# Altium schematic sheet ArduinoUNO.SchDoc
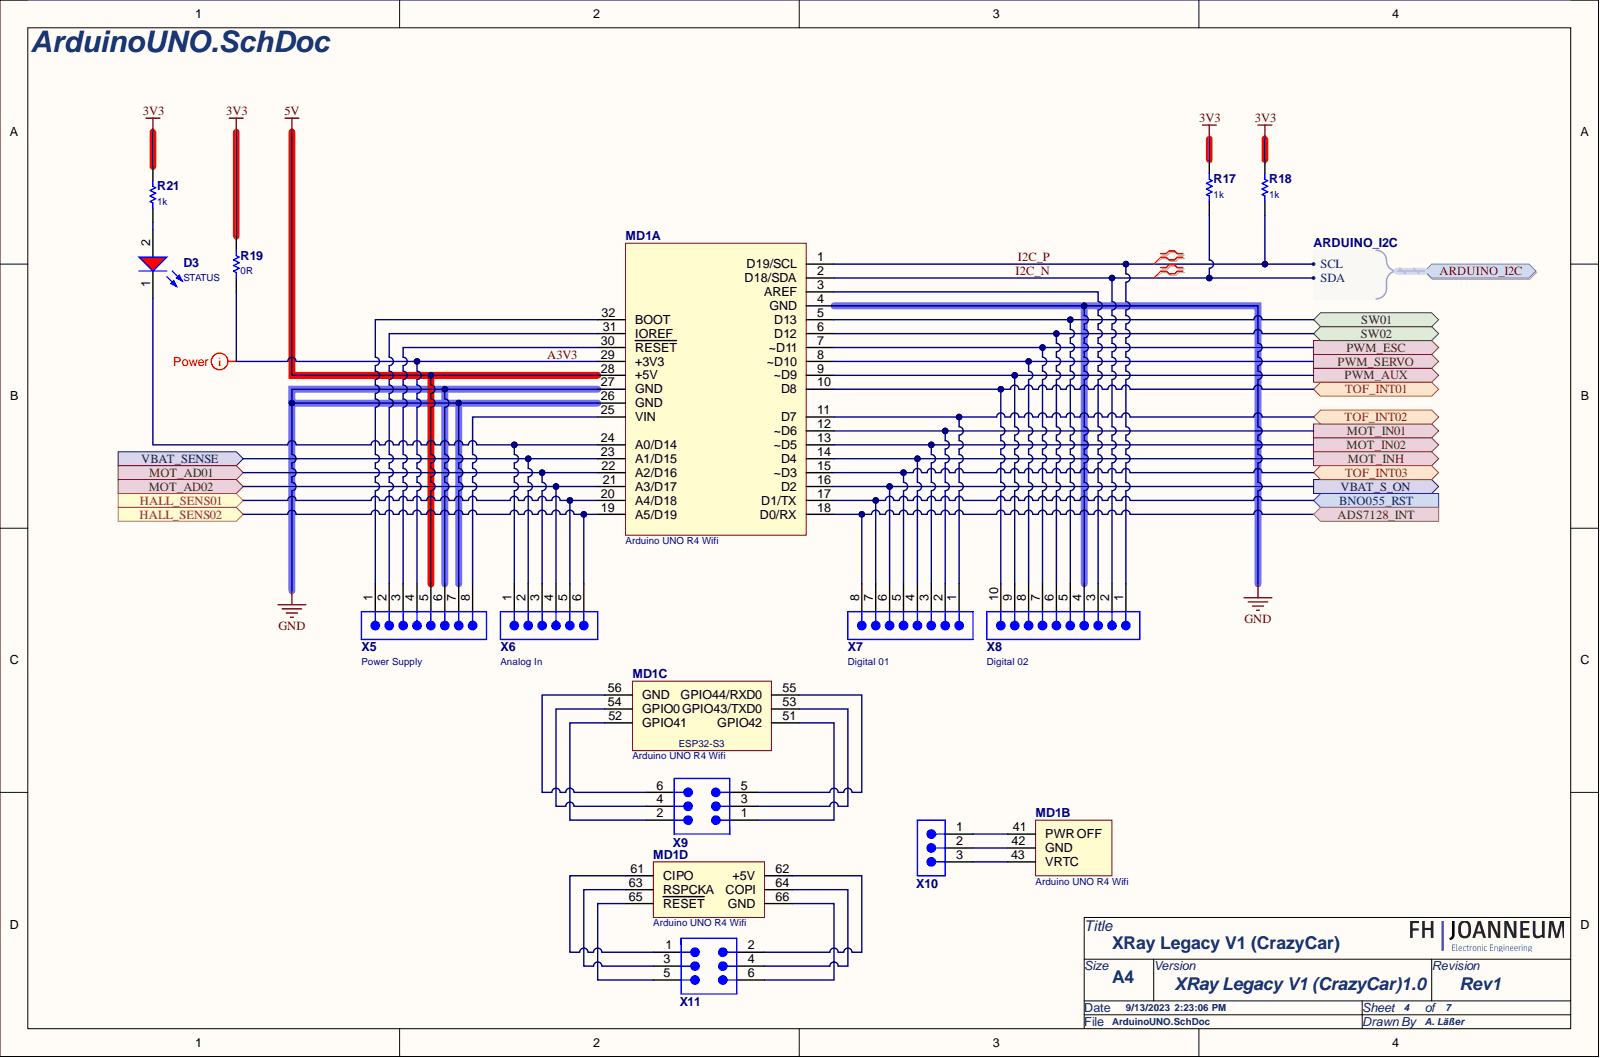
[diagram p0: ArduinoUNO.SchDoc]

## Parts
D3  STATUS  [WL-SMCC_0603_L1.6W0.8H0.2]
MD1  Arduino UNO R4 Wifi  [ARDUINO UNO R4 Wiki]  (parts A,B,C,D)
R17  Resistance: 1k  [RESC2013X60X35ML10T20]  (hidden comment: RC0805FR-071KL)
R18  Resistance: 1k  [RESC2013X60X35ML10T20]  (hidden comment: RC0805FR-071KL)
R19  Resistance: 0R  [RESC2013X60X35ML10T20]  (hidden comment: RC0805JR-070RL)
R21  Resistance: 1k  [RESC2013X60X35ML10T20]  (hidden comment: RC0805FR-071KL)
X5  Power Supply  [61300811121]
X6  Analog In  [61300611121]
X7  Digital 01  [61300811121]
X8  Digital 02  [61301011121]
X9  61300621121  [61300621121]  (value not drawn)
X10  61300311121  [61300311121]  (value not drawn)
X11  61300621121  [61300621121]  (value not drawn)

## Ports
ADS7128_INT, ARDUINO_I2C, ARDUINO_I2C.SCL, ARDUINO_I2C.SDA, BNO055_RST, HALL_SENS01, HALL_SENS02, MOT_AD01, MOT_AD02, MOT_IN01, MOT_IN02, MOT_INH, PWM_AUX, PWM_ESC, PWM_SERVO, SW01, SW02, TOF_INT01, TOF_INT02, TOF_INT03, VBAT_S_ON, VBAT_SENSE

## Nets
3V3: R17.1 R18.1 R19.2 R21.1
5V: MD1.28(+5V) X5.5
GND: MD1.4(GND) MD1.26(GND) MD1.27(GND) X5.6 X5.7 X8.4
A3V3: MD1.29(+3V3) R19.1 X5.4
ADS7128_INT: MD1.18(D0/RX) X7.8 ; port ADS7128_INT
BNO055_RST: MD1.17(D1/TX) X7.7 ; port BNO055_RST
HALL_SENS01: MD1.20(A4/D18) X6.5 ; port HALL_SENS01
HALL_SENS02: MD1.19(A5/D19) X6.6 ; port HALL_SENS02
I2C_N: MD1.2(D18/SDA) R17.2 X8.2 ; port ARDUINO_I2C
I2C_P: MD1.1(D19/SCL) R18.2 X8.1 ; port ARDUINO_I2C
MOT_AD01: MD1.22(A2/D16) X6.3 ; port MOT_AD01
MOT_AD02: MD1.21(A3/D17) X6.4 ; port MOT_AD02
MOT_IN01: MD1.12(~D6) X7.2 ; port MOT_IN01
MOT_IN02: MD1.13(~D5) X7.3 ; port MOT_IN02
MOT_INH: MD1.14(D4) X7.4 ; port MOT_INH
PWM_AUX: MD1.9(~D9) X8.9 ; port PWM_AUX
PWM_ESC: MD1.7(~D11) X8.7 ; port PWM_ESC
PWM_SERVO: MD1.8(~D10) X8.8 ; port PWM_SERVO
SW01: MD1.5(D13) X8.5 ; port SW01
SW02: MD1.6(D12) X8.6 ; port SW02
TOF_INT01: MD1.10(D8) X8.10 ; port TOF_INT01
TOF_INT02: MD1.11(D7) X7.1 ; port TOF_INT02
TOF_INT03: MD1.15(~D3) X7.5 ; port TOF_INT03
VBAT_S_ON: MD1.16(D2) X7.6 ; port VBAT_S_ON
VBAT_SENSE: MD1.23(A1/D15) X6.2 ; port VBAT_SENSE
NetD3_1: D3.1 MD1.24(A0/D14) X6.1
NetD3_2: D3.2 R21.2
NetMD1_3: MD1.3(AREF) X8.3
NetMD1_25: MD1.25(VIN) X5.8
NetMD1_30: MD1.30(RESET) X5.3
NetMD1_31: MD1.31(IOREF) X5.2
NetMD1_32: MD1.32(BOOT) X5.1
NetMD1_41: MD1.41(PWR_OFF) X10.1
NetMD1_42: MD1.42(GND) X10.2
NetMD1_43: MD1.43(VRTC) X10.3
NetMD1_51: MD1.51(GPIO42) X9.1
NetMD1_52: MD1.52(GPIO41) X9.2
NetMD1_53: MD1.53(GPIO43/TXD0) X9.3
NetMD1_54: MD1.54(GPIO0) X9.4
NetMD1_55: MD1.55(GPIO44/RXD0) X9.5
NetMD1_56: MD1.56(GND) X9.6
NetMD1_61: MD1.61(CIPO) X11.1
NetMD1_62: MD1.62(+5V) X11.2
NetMD1_63: MD1.63(RSPCKA) X11.3
NetMD1_64: MD1.64(COPI) X11.4
NetMD1_65: MD1.65(RESET) X11.5
NetMD1_66: MD1.66(GND) X11.6

## Library data of the parts
D3 = 150060RS73220: WL-SMCC Mono-color Chip LED Compact, size 0603, Red, 2V, 120°; Manufacturer: Wurth Elektronik; Case/Size Code: 0603; Chip Technology: AlInGaP; Dominant Wavelength: 624nm; Luminous Intensity: 90mcd; Peak Wavelength: 632nm; Category: Signal LEDs; Component Kind: Standard; ComponentLink1Description: Website Link; ComponentLink1URL: https://www.we-online.com/redexpert/article/150060RS73220?ad; ComponentLink2Description: Datasheet Link; ComponentLink2URL: https://www.we-online.com/redexpert/spec/150060RS73220?ad; Emitting Color: Red; Forward Voltage: 2V; Height: 0.2mm; Length: 1.6mm; Library Name: Altium_WL-SMCC (rev22b).IntLib; Manufacturer Part Number: 150060RS73220; Match Code: WL-SMCC; Mount: Surface Mount; Operating Temperature Max: 85°C; Operating Temperature Min: -40°C; Packaging: Tape and Reel; Simulation: 0603_150060RS73220; Viewing Angle: 120°; Width: 0.8mm
R17 R18 R21 = CMP-2100-03671-1: Chip Resistor, 1 KOhm, +/- 1%, 125 mW, -55 to 155 degC, 0805 (2012 Metric), RoHS, Tape and Reel; Manufacturer: Yageo; Additional Feature: LASER TRIMMABLE; Max Power Dissipation: 125mW; Package Height: 0.5mm; Package Length: 2mm; Package Width: 1.25mm; Rated Temperature: 70°C; Temperature Coefficient: 100ppm/degreesC; Voltage Rating: 150V; Case Code (Imperial): 0805; Case Code (Metric): 2012; Case/Package: 0805; Category: Chip SMD Resistors; Component Kind: Standard; ComponentLink1Description: https://datasheet.ciiva.com:443/pdfs/VipMasterIC/IC/YAGO/YAGO-S-A0008145938/YAGO-S-A0008145938-1.pdf?src-supplier=IHS+Markit; ComponentLink1URL: https://datasheet.ciiva.com:443/pdfs/VipMasterIC/IC/YAGO/YAGO-S-A0008145938/YAGO-S-A0008145938-1.pdf?src-supplier=IHS+Markit; ComponentLink2Description: https://datasheet.ciiva.com/7941/1686128-7941719.pdf?src-supplier=Element14; ComponentLink2URL: https://datasheet.ciiva.com/7941/1686128-7941719.pdf?src-supplier=Element14; ComponentLink3Description: https://datasheet.ciiva.com/46385/444164-46385020.pdf?src-supplier=Element14; ComponentLink3URL: https://datasheet.ciiva.com/46385/444164-46385020.pdf?src-supplier=Element14; ComponentLink4Description: https://datasheet.ciiva.com/2456/pyu-r-int-thick-7-2456976.pdf?src-supplier=Digi-Key; ComponentLink4URL: https://datasheet.ciiva.com/2456/pyu-r-int-thick-7-2456976.pdf?src-supplier=Digi-Key; ComponentLink5Description: https://datasheet.ciiva.com/2456/pyu-r-int-thick-7-2456977.pdf?src-supplier=Digi-Key; ComponentLink5URL: https://datasheet.ciiva.com/2456/pyu-r-int-thick-7-2456977.pdf?src-supplier=Digi-Key; ComponentLink6Description: https://datasheet.ciiva.com/2456/pyu-r-int-thick-7-2456975.pdf?src-supplier=Digi-Key; ComponentLink6URL: https://datasheet.ciiva.com/2456/pyu-r-int-thick-7-2456975.pdf?src-supplier=Digi-Key; ComponentLink7Description: https://datasheet.ciiva.com/16850/rc0805-16850409.pdf?src-supplier=Future+Electronics; ComponentLink7URL: https://datasheet.ciiva.com/16850/rc0805-16850409.pdf?src-supplier=Future+Electronics; Composition: ThickFilm; Construction: Chip; Datasheet URL: http://www.yageo.com/NewPortal/yageodocoutput?fileName=/pdf/R-Chip/PYu-RC_Group_51_RoHS_L_5.pdf; Datasheet Version: Rev. 5, 10/2016; Depth: 1.25mm; Height: 0.024inch; JESD-609 Code: e3; Lead Free: LeadFree; Length: 0.079inch; Library Name: Altium Content Vault; Manufacturer Part Number: RC0805FR-071KL; Manufacturer URL: http://www.yageo.com/; Max Operating Temperature: 155degC; Military Standard: Not; Min Operating Temperature: -55degC; Mount: Surface Mount; Number of Pins: 2; Number of Terminations: 2; Package Description: 2-Pin Surface Mounted Device, Body 2 x 1.25 mm; Package Quantity: 1; Package Reference: 0805; Package Shape: Rectangular; Package Style: SMTMeter; Packaging: TapeandReel; Power Rating: 125mW; Pricing 1: 5000=0.00500 (USD); Rated Power Dissipation (P): 125mW; REACH SVHC: Unknown; Resistor Type: FIXED RESISTOR; RoHS: Yes; RoHS Compliant: Yes; RoHSCompliant: true; Size Code: 0805; Stock 1: 490000; Supplier 1: RSComponents; Supplier Part Number 1: 6183583; Technology: METAL GLAZE/THICK FILM; Terminal Finish: Nickel; Termination: SMD/SMT; Tolerance: 1%; Voltage Rating (DC): 150V; Width: 0.049inch; Working Voltage: 150V
R19 = CMP-2100-03673-1: Chip Resistor, 0 Ohm, Jumper, +/- 5%, 0.125 W, -55 to 155 degC, 0805 (2012 Metric), RoHS, Tape and Reel; Manufacturer: Yageo; Package Height: 0.5mm; Package Length: 2mm; Package Width: 1.25mm; Power Rating: 125mW; Rated Temperature: 70°C; Temperature Coefficient: 200ppm/degreesC; Voltage Rating: 150V; Case Code (Imperial): 0805; Case Code (Metric): 2012; Case/Package: 0805; Category: Chip SMD Resistors; Component Kind: Standard; ComponentLink1Description: https://datasheet.ciiva.com:443/pdfs/VipMasterIC/IC/YAGO/YAGO-S-A0008145938/YAGO-S-A0008145938-1.pdf?src-supplier=IHS+Markit; ComponentLink1URL: https://datasheet.ciiva.com:443/pdfs/VipMasterIC/IC/YAGO/YAGO-S-A0008145938/YAGO-S-A0008145938-1.pdf?src-supplier=IHS+Markit; ComponentLink2Description: https://datasheet.ciiva.com/11819/0900766b8106beab-11819430.pdf?src-supplier=RSComponents; ComponentLink2URL: https://datasheet.ciiva.com/11819/0900766b8106beab-11819430.pdf?src-supplier=RSComponents; ComponentLink3Description: https://datasheet.ciiva.com/7941/1738694-7941861.pdf?src-supplier=Element14; ComponentLink3URL: https://datasheet.ciiva.com/7941/1738694-7941861.pdf?src-supplier=Element14; ComponentLink4Description: https://datasheet.ciiva.com/7941/1738694-7941862.pdf?src-supplier=Element14; ComponentLink4URL: https://datasheet.ciiva.com/7941/1738694-7941862.pdf?src-supplier=Element14; ComponentLink5Description: https://datasheet.ciiva.com/7941/1738694-7941863.pdf?src-supplier=Element14; ComponentLink5URL: https://datasheet.ciiva.com/7941/1738694-7941863.pdf?src-supplier=Element14; ComponentLink6Description: https://datasheet.ciiva.com/15190/getdatasheetpartid-330685-15190028.pdf?src-supplier=Verical; ComponentLink6URL: https://datasheet.ciiva.com/15190/getdatasheetpartid-330685-15190028.pdf?src-supplier=Verical; Composition: ThickFilm; Construction: Chip; Contact Plating: Tin; Datasheet URL: http://www.yageo.com/NewPortal/yageodocoutput?fileName=/pdf/R-Chip/PYu-RC_Group_51_RoHS_L_5.pdf; Datasheet Version: Rev. 5, 10/2016; Depth: 1.25mm; Height: 0.5mm; JESD-609 Code: e3; Lead Free: LeadFree; Length: 2mm; Library Name: Altium Content Vault; Manufacturer Part Number: RC0805JR-070RL; Manufacturer URL: http://www.yageo.com/; Max Operating Temperature: 155degC; Military Standard: Not; Min Operating Temperature: -55degC; Mount: Surface Mount; Number of Pins: 2; Package Description: 2-Pin Surface Mounted Device, Body 2 x 1.25 mm; Package Reference: 0805; Package Shape: Rectangular; Package Style: SMTMeter; Packaging: TapeandReel; Pricing 1: 100=0.00700, 1000=0.00700, 2500=0.00600, 5000=0.00600, 10000=0.00500 (GBP); Radiation Hardening: No; Rated Power Dissipation (P): 125mW; REACH SVHC: Unknown; Resistor Type: FIXED RESISTOR; RoHS: Yes; RoHS Compliant: Yes; RoHSCompliant: true; Size Code: 0805; Stock 1: 1700; Supplier 1: RSComponents; Supplier Part Number 1: 1995753; Technology: METAL GLAZE/THICK FILM; Terminal Finish: Nickel; Termination: SMD/SMT; Tolerance: 5%; Voltage Rating (DC): 150V; Width: 1.25mm
X5 X7 = 61300811121: WR-PHD Pin Header, THT, Vertical, pitch 2.54mm, 1 Row, 8P; Manufacturer: Wurth Elektronik; Contact Resistance: 20mΩ; Rated Current: 3A; Withstanding Voltage: 500V; Working Voltage: 250V; Category: Board-to-Board Connectors; Component Kind: Standard; ComponentLink1Description: Website Link; ComponentLink1URL: https://www.we-online.com/redexpert/article/61300811121?ad; ComponentLink2Description: Datasheet Link; ComponentLink2URL: https://www.we-online.com/redexpert/spec/61300811121?ad; Gender: Pin Header; Library Name: Altium_WR-PHD (rev22b).IntLib; Manufacturer Part Number: 61300811121; Match Code: WR-PHD; Mount: Through Hole; Number of Pins: 8; Number of Rows: 1; Operating Temperature Max: 105°C; Operating Temperature Min: -40°C; Orientation: Vertical; Packaging: Bag; Pitch: 2.54mm
X6 = 61300611121: WR-PHD Pin Header, THT, Vertical, pitch 2.54mm, 1 Row, 6P; Manufacturer: Wurth Elektronik; Contact Resistance: 20mΩ; Rated Current: 3A; Withstanding Voltage: 500V; Working Voltage: 250V; Category: Board-to-Board Connectors; Component Kind: Standard; ComponentLink1Description: Website Link; ComponentLink1URL: https://www.we-online.com/redexpert/article/61300611121?ad; ComponentLink2Description: Datasheet Link; ComponentLink2URL: https://www.we-online.com/redexpert/spec/61300611121?ad; Gender: Pin Header; Library Name: Altium_WR-PHD (rev22b).IntLib; Manufacturer Part Number: 61300611121; Match Code: WR-PHD; Mount: Through Hole; Number of Pins: 6; Number of Rows: 1; Operating Temperature Max: 105°C; Operating Temperature Min: -40°C; Orientation: Vertical; Packaging: Bag; Pitch: 2.54mm
X8 = 61301011121: WR-PHD Pin Header, THT, Vertical, pitch 2.54mm, 1 Row, 10P; Manufacturer: Wurth Elektronik; Contact Resistance: 20mΩ; Rated Current: 3A; Withstanding Voltage: 500V; Working Voltage: 250V; Category: Board-to-Board Connectors; Component Kind: Standard; ComponentLink1Description: Website Link; ComponentLink1URL: https://www.we-online.com/redexpert/article/61301011121?ad; ComponentLink2Description: Datasheet Link; ComponentLink2URL: https://www.we-online.com/redexpert/spec/61301011121?ad; Gender: Pin Header; Library Name: Altium_WR-PHD (rev22b).IntLib; Manufacturer Part Number: 61301011121; Match Code: WR-PHD; Mount: Through Hole; Number of Pins: 10; Number of Rows: 1; Operating Temperature Max: 105°C; Operating Temperature Min: -40°C; Orientation: Vertical; Packaging: Bag; Pitch: 2.54mm
X9 X11 = 61300621121: WR-PHD Pin Header, THT, Vertical, pitch 2.54mm, 2 Row, 6P; Manufacturer: Wurth Elektronik; Contact Resistance: 20mΩ; Rated Current: 3A; Withstanding Voltage: 500V; Working Voltage: 250V; Category: Board-to-Board Connectors; Component Kind: Standard; ComponentLink1Description: Website Link; ComponentLink1URL: https://www.we-online.com/redexpert/article/61300621121?ad; ComponentLink2Description: Datasheet Link; ComponentLink2URL: https://www.we-online.com/redexpert/spec/61300621121?ad; Gender: Pin Header; Library Name: Altium_WR-PHD (rev22b).IntLib; Manufacturer Part Number: 61300621121; Match Code: WR-PHD; Mount: Through Hole; Number of Pins: 6; Number of Rows: 2; Operating Temperature Max: 105°C; Operating Temperature Min: -40°C; Orientation: Vertical; Packaging: Bag; Pitch: 2.54mm
X10 = 61300311121: WR-PHD Pin Header, THT, Vertical, pitch 2.54mm, 1 Row, 3P; Manufacturer: Wurth Elektronik; Contact Resistance: 20mΩ; Rated Current: 3A; Withstanding Voltage: 500V; Working Voltage: 250V; Category: Board-to-Board Connectors; Component Kind: Standard; ComponentLink1Description: Website Link; ComponentLink1URL: https://www.we-online.com/redexpert/article/61300311121?ad; ComponentLink2Description: Datasheet Link; ComponentLink2URL: https://www.we-online.com/redexpert/spec/61300311121?ad; Gender: Pin Header; Library Name: Altium_WR-PHD (rev22b).IntLib; Manufacturer Part Number: 61300311121; Match Code: WR-PHD; Mount: Through Hole; Number of Pins: 3; Number of Rows: 1; Operating Temperature Max: 105°C; Operating Temperature Min: -40°C; Orientation: Vertical; Packaging: Bag; Pitch: 2.54mm
MD1 = Arduino UNO R4 Wifi: Component Kind: Standard; Library Name: Schlib1.SchLib
